# Altium schematic sheet cpu.SchDoc(cpu)
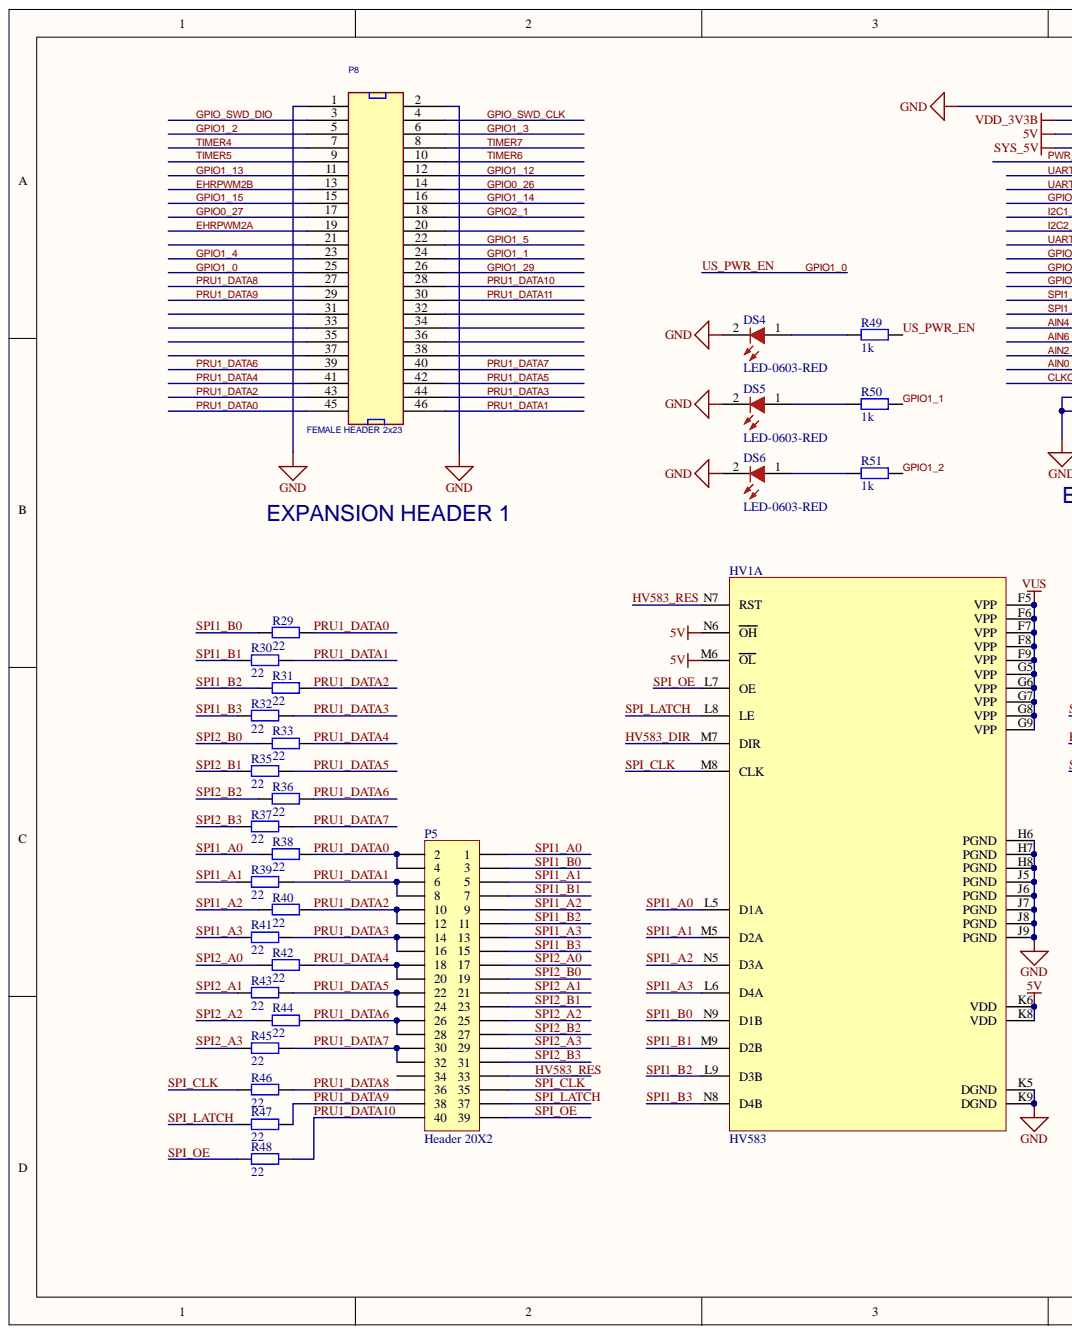
[diagram p0: cpu.SchDoc, left]
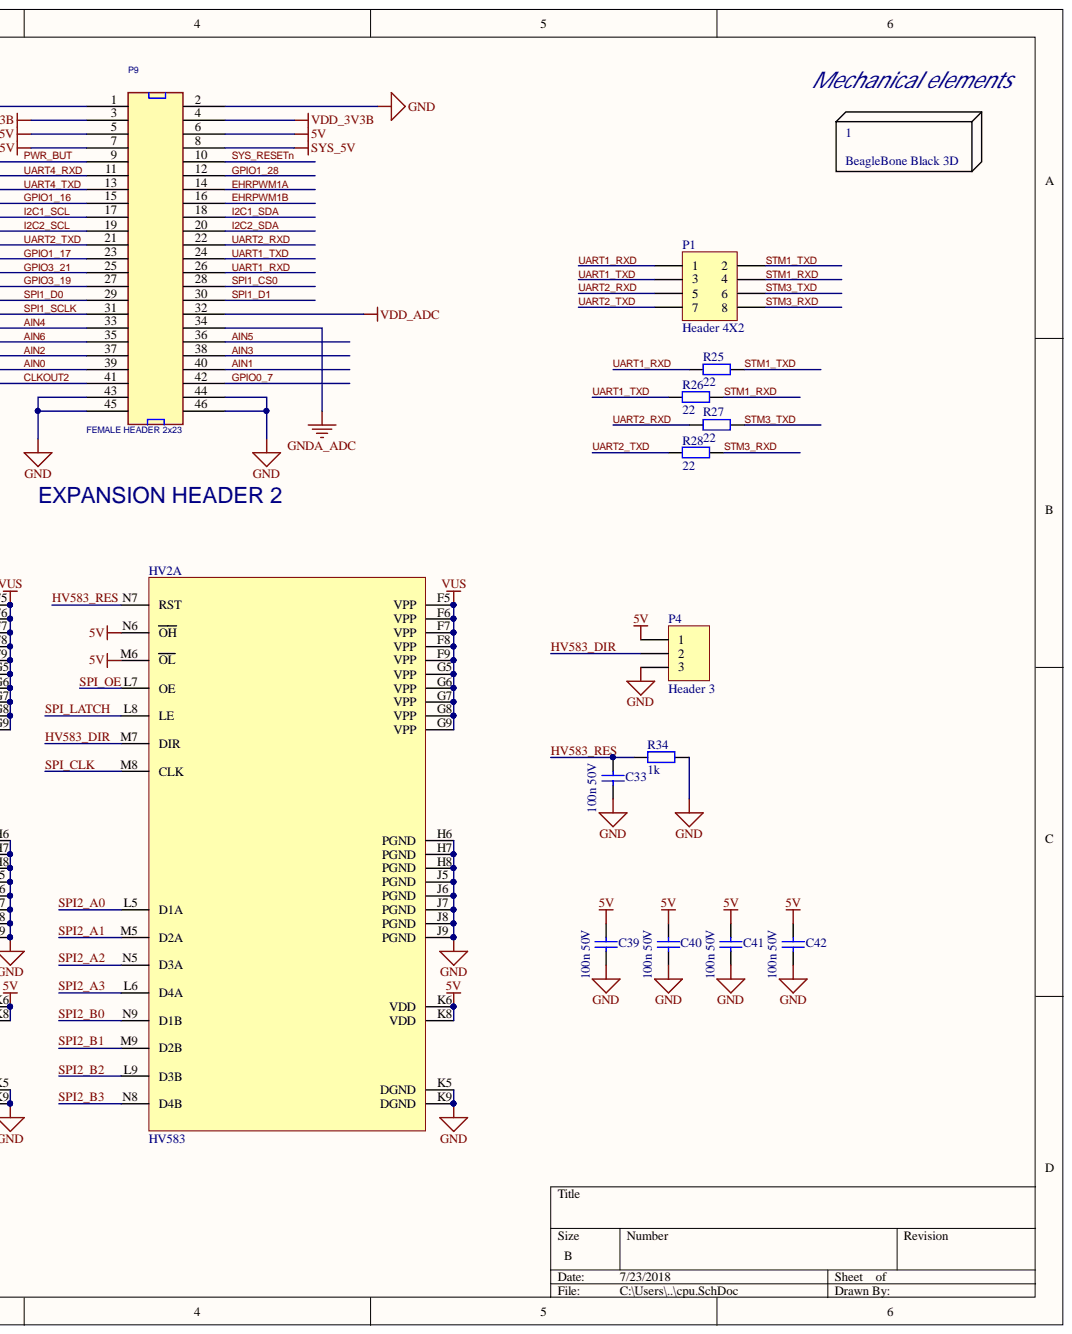
[diagram p1: cpu.SchDoc, right]

## Parts
1  BeagleBone Black 3D
C33  100n 50V  [C-0603-H]
C39  100n 50V  [C-0603-H]
C40  100n 50V  [C-0603-H]
C41  100n 50V  [C-0603-H]
C42  100n 50V  [C-0603-H]
DS4  LED-0603-RED  [LED-0603-RED]
DS5  LED-0603-RED  [LED-0603-RED]
DS6  LED-0603-RED  [LED-0603-RED]
HV1  HV583  [BGA169x13_0.26balls]  (part A)
HV2  HV583  [BGA169x13_0.26balls]  (part A)
P1  Header 4X2  [HDR2X4]
P4  Header 3  [HDR1X3]
P5  Header 20X2  [HDR2X20]
P8  FEMALE HEADER 2x23  [HEADER23X2]
P9  FEMALE HEADER 2x23  [HEADER23X2]
R25  22  [R-0402-H]
R26  22  [R-0402-H]
R27  22  [R-0402-H]
R28  22  [R-0402-H]
R29  22  [R-0402-H]
R30  22  [R-0402-H]
R31  22  [R-0402-H]
R32  22  [R-0402-H]
R33  22  [R-0402-H]
R34  1k  [R-0603-M]
R35  22  [R-0402-H]
R36  22  [R-0402-H]
R37  22  [R-0402-H]
R38  22  [R-0402-H]
R39  22  [R-0402-H]
R40  22  [R-0402-H]
R41  22  [R-0402-H]
R42  22  [R-0402-H]
R43  22  [R-0402-H]
R44  22  [R-0402-H]
R45  22  [R-0402-H]
R46  22  [R-0402-H]
R47  22  [R-0402-H]
R48  22  [R-0402-H]
R49  1k  [R-0603-M]
R50  1k  [R-0603-M]
R51  1k  [R-0603-M]

## Nets
5V: C39.2 C40.2 C41.2 C42.2 HV1.K6(VDD) HV1.K8(VDD) HV1.M6(OL) HV1.N6(OH) HV2.K6(VDD) HV2.K8(VDD) HV2.M6(OL) HV2.N6(OH) P4.1 P9.5 P9.6
GND: C33.1 C39.1 C40.1 C41.1 C42.1 DS4.2 DS5.2 DS6.2 HV1.H6(PGND) HV1.H7(PGND) HV1.H8(PGND) HV1.J5(PGND) HV1.J6(PGND) HV1.J7(PGND) HV1.J8(PGND) HV1.J9(PGND) HV1.K5(DGND) HV1.K9(DGND) HV2.H6(PGND) HV2.H7(PGND) HV2.H8(PGND) HV2.J5(PGND) HV2.J6(PGND) HV2.J7(PGND) HV2.J8(PGND) HV2.J9(PGND) HV2.K5(DGND) HV2.K9(DGND) P4.3 P8.1 P8.2 P9.1 P9.2 P9.43 P9.44 P9.45 P9.46 R34.2
GNDA_ADC: P9.34
SYS_5V: P9.7 P9.8
VDD_3V3B: P9.3 P9.4
VDD_ADC: P9.32
VUS: HV1.F5(VPP) HV1.F6(VPP) HV1.F7(VPP) HV1.F8(VPP) HV1.F9(VPP) HV1.G5(VPP) HV1.G6(VPP) HV1.G7(VPP) HV1.G8(VPP) HV1.G9(VPP) HV2.F5(VPP) HV2.F6(VPP) HV2.F7(VPP) HV2.F8(VPP) HV2.F9(VPP) HV2.G5(VPP) HV2.G6(VPP) HV2.G7(VPP) HV2.G8(VPP) HV2.G9(VPP)
AIN0: P9.39
AIN1: P9.40
AIN2: P9.37
AIN3: P9.38
AIN4: P9.33
AIN5: P9.36
AIN6: P9.35
CLKOUT2: P9.41
EHRPWM1A: P9.14
EHRPWM1B: P9.16
EHRPWM2A: P8.19
EHRPWM2B: P8.13
GPIO0_7: P9.42
GPIO0_26: P8.14
GPIO0_27: P8.17
GPIO1_0: P8.25 R49.1
GPIO1_1: P8.24 R50.1
GPIO1_2: P8.5 R51.1
GPIO1_3: P8.6
GPIO1_4: P8.23
GPIO1_5: P8.22
GPIO1_12: P8.12
GPIO1_13: P8.11
GPIO1_14: P8.16
GPIO1_15: P8.15
GPIO1_16: P9.15
GPIO1_17: P9.23
GPIO1_28: P9.12
GPIO1_29: P8.26
GPIO2_1: P8.18
GPIO3_19: P9.27
GPIO3_21: P9.25
GPIO_SWD_CLK: P8.4
GPIO_SWD_DIO: P8.3
HV583_DIR: HV1.M7(DIR) HV2.M7(DIR) P4.2
HV583_RES: C33.2 HV1.N7(RST) HV2.N7(RST) P5.33 R34.1
I2C1_SCL: P9.17
I2C1_SDA: P9.18
I2C2_SCL: P9.19
I2C2_SDA: P9.20
PRU1_DATA0: P5.2 P5.4 P8.45 R29.2 R38.2
PRU1_DATA1: P5.6 P5.8 P8.46 R30.2 R39.2
PRU1_DATA2: P5.10 P5.12 P8.43 R31.2 R40.2
PRU1_DATA3: P5.14 P5.16 P8.44 R32.2 R41.2
PRU1_DATA4: P5.18 P5.20 P8.41 R33.2 R42.2
PRU1_DATA5: P5.22 P5.24 P8.42 R35.2 R43.2
PRU1_DATA6: P5.26 P5.28 P8.39 R36.2 R44.2
PRU1_DATA7: P5.30 P5.32 P8.40 R37.2 R45.2
PRU1_DATA8: P5.36 P8.27 R46.2
PRU1_DATA9: P5.38 P8.29 R47.2
PRU1_DATA10: P5.40 P8.28 R48.2
PRU1_DATA11: P8.30
PWR_BUT: P9.9
SPI1_A0: HV1.L5(D1A) P5.1 R38.1
SPI1_A1: HV1.M5(D2A) P5.5 R39.1
SPI1_A2: HV1.N5(D3A) P5.9 R40.1
SPI1_A3: HV1.L6(D4A) P5.13 R41.1
SPI1_B0: HV1.N9(D1B) P5.3 R29.1
SPI1_B1: HV1.M9(D2B) P5.7 R30.1
SPI1_B2: HV1.L9(D3B) P5.11 R31.1
SPI1_B3: HV1.N8(D4B) P5.15 R32.1
SPI1_CS0: P9.28
SPI1_D0: P9.29
SPI1_D1: P9.30
SPI1_SCLK: P9.31
SPI2_A0: HV2.L5(D1A) P5.17 R42.1
SPI2_A1: HV2.M5(D2A) P5.21 R43.1
SPI2_A2: HV2.N5(D3A) P5.25 R44.1
SPI2_A3: HV2.L6(D4A) P5.29 R45.1
SPI2_B0: HV2.N9(D1B) P5.19 R33.1
SPI2_B1: HV2.M9(D2B) P5.23 R35.1
SPI2_B2: HV2.L9(D3B) P5.27 R36.1
SPI2_B3: HV2.N8(D4B) P5.31 R37.1
SPI_CLK: HV1.M8(CLK) HV2.M8(CLK) P5.35 R46.1
SPI_LATCH: HV1.L8(LE) HV2.L8(LE) P5.37 R47.1
SPI_OE: HV1.L7(OE) HV2.L7(OE) P5.39 R48.1
STM1_RXD: P1.4 R26.2
STM1_TXD: P1.2 R25.2
STM3_RXD: P1.8 R28.2
STM3_TXD: P1.6 R27.2
SYS_RESETn: P9.10
TIMER4: P8.7
TIMER5: P8.9
TIMER6: P8.10
TIMER7: P8.8
UART1_RXD: P1.1 P9.26 R25.1
UART1_TXD: P1.3 P9.24 R26.1
UART2_RXD: P1.5 P9.22 R27.1
UART2_TXD: P1.7 P9.21 R28.1
UART4_RXD: P9.11
UART4_TXD: P9.13
NetDS4_1: DS4.1 R49.2
NetDS5_1: DS5.1 R50.2
NetDS6_1: DS6.1 R51.2

Not connected: P5 34; P8 20 21 31 32 33 34 35 36 37 38

## Library data of the parts
C33 C39-C42 = C-0603-100n-50V: Capacitor 100n 50V; Supplier Part Number 1: 80-C0603C104K5RAUTO; Supplier 1: Mouser; Library Name: Passives.IntLib; Component Kind: Standard
HV1 HV2 = HV583: 128bit high voltage shift register; Library Name: Microchip_HV58x.SchLib; Component Kind: Standard
P1 = Header 4X2: Header, 4-Pin, Dual row; Signal Integrity: Connector; Library Name: Miscellaneous Connectors.IntLib; LatestRevisionNote: Re-released for DXP Platform.; LatestRevisionDate: 17-Jul-2002; Component Kind: Standard; Publisher: Altium Limited
P4 = Header 3: Header, 3-Pin; Signal Integrity: Connector; Library Name: Miscellaneous Connectors.IntLib; LatestRevisionNote: Re-released for DXP Platform.; LatestRevisionDate: 17-Jul-2002; Component Kind: Standard; Publisher: Altium Limited
P5 = Header 20X2: Header, 20-Pin, Dual row; Signal Integrity: Connector; Library Name: Miscellaneous Connectors.IntLib; LatestRevisionNote: Re-released for DXP Platform.; LatestRevisionDate: 17-Jul-2002; Component Kind: Standard; Publisher: Altium Limited
P8 P9 = HDR_46_F_2_0.1_0.1: CONN HEADER FMAL 46PS.1" DL GOLD; Manufacturer Part Number: PPPC232LFBN-RC; Manufacturer: Sullins Connector Solutions; Row Spacing: 0.100" (2.54mm); Number of Positions Loaded: All; Number of Positions: 46; Termination: Solder; Supplier Part Number 1: S7126-ND; Supplier 1: Digi-Key; Stock 1: 750; RoHS: RoHS Compliant; Pricing 1: 1=2.75, 10=2.325, 25=2.2196, 50=2.0084, 100=1.7969, 250=1.58552, 500=1.4798, 1000=1.3741, 2500=1.32125 (USD); Pitch: 0.100" (2.54mm); Packaging: Bulk; Number of Rows: 2; Mounting Type: Through Hole; Features: -; Fastening Type: -; Contact Type: Female Socket; Contact Finish Thickness: Flash; Contact Finish: Gold; Connector Type: Header; ComponentLink1URL: http://www.sullinscorp.com/drawings/78_P(N)PxCxxxLFBN-RC,_10492-G.pdf; ComponentLink1Description: http://www.sullinscorp.com/drawings/78_P(N)PxCxxxLFBN-RC,_10492-G.pdf; Component Kind: Standard; Color: Black; Category: Connectors, Interconnects; Library Name: BEAGLEBONEBLACK_REV A5B.SCHLIB
  P9: PCB3D: pin_header_a-sl200-dg-g46d
R25-R33 R35-R48 = R-0402-22: Resistor 22; Supplier Part Number 1: 71-CRCW0402-22-E3; Supplier 1: Mouser; Library Name: Passives.IntLib; Component Kind: Standard
R34 = R-0603-47k: Resistor 4k7; Supplier Part Number 1: 71-CRCW060347K0FKEB; Supplier 1: Mouser; Library Name: Passives.IntLib; Component Kind: Standard
R49-R51 = R-0603-1k: Resistor 1k; Supplier Part Number 1: 71-CRCW0603-1.0K-E3; Supplier 1: Mouser; Library Name: Passives.IntLib; Component Kind: Standard
1 = BeagleBone Black 3D: PCB3D: BEAGLEBONE_BLACK; Component Kind: Standard; Library Name: Stamp.SchLib
DS4-DS6 = LED-0603-RED: Supplier Part Number 1: 859-LTST-C190EKT; Supplier 1: Mouser; Library Name: advanced.SchLib; Component Kind: Standard
